# Altium schematic sheet PORT.SchDoc
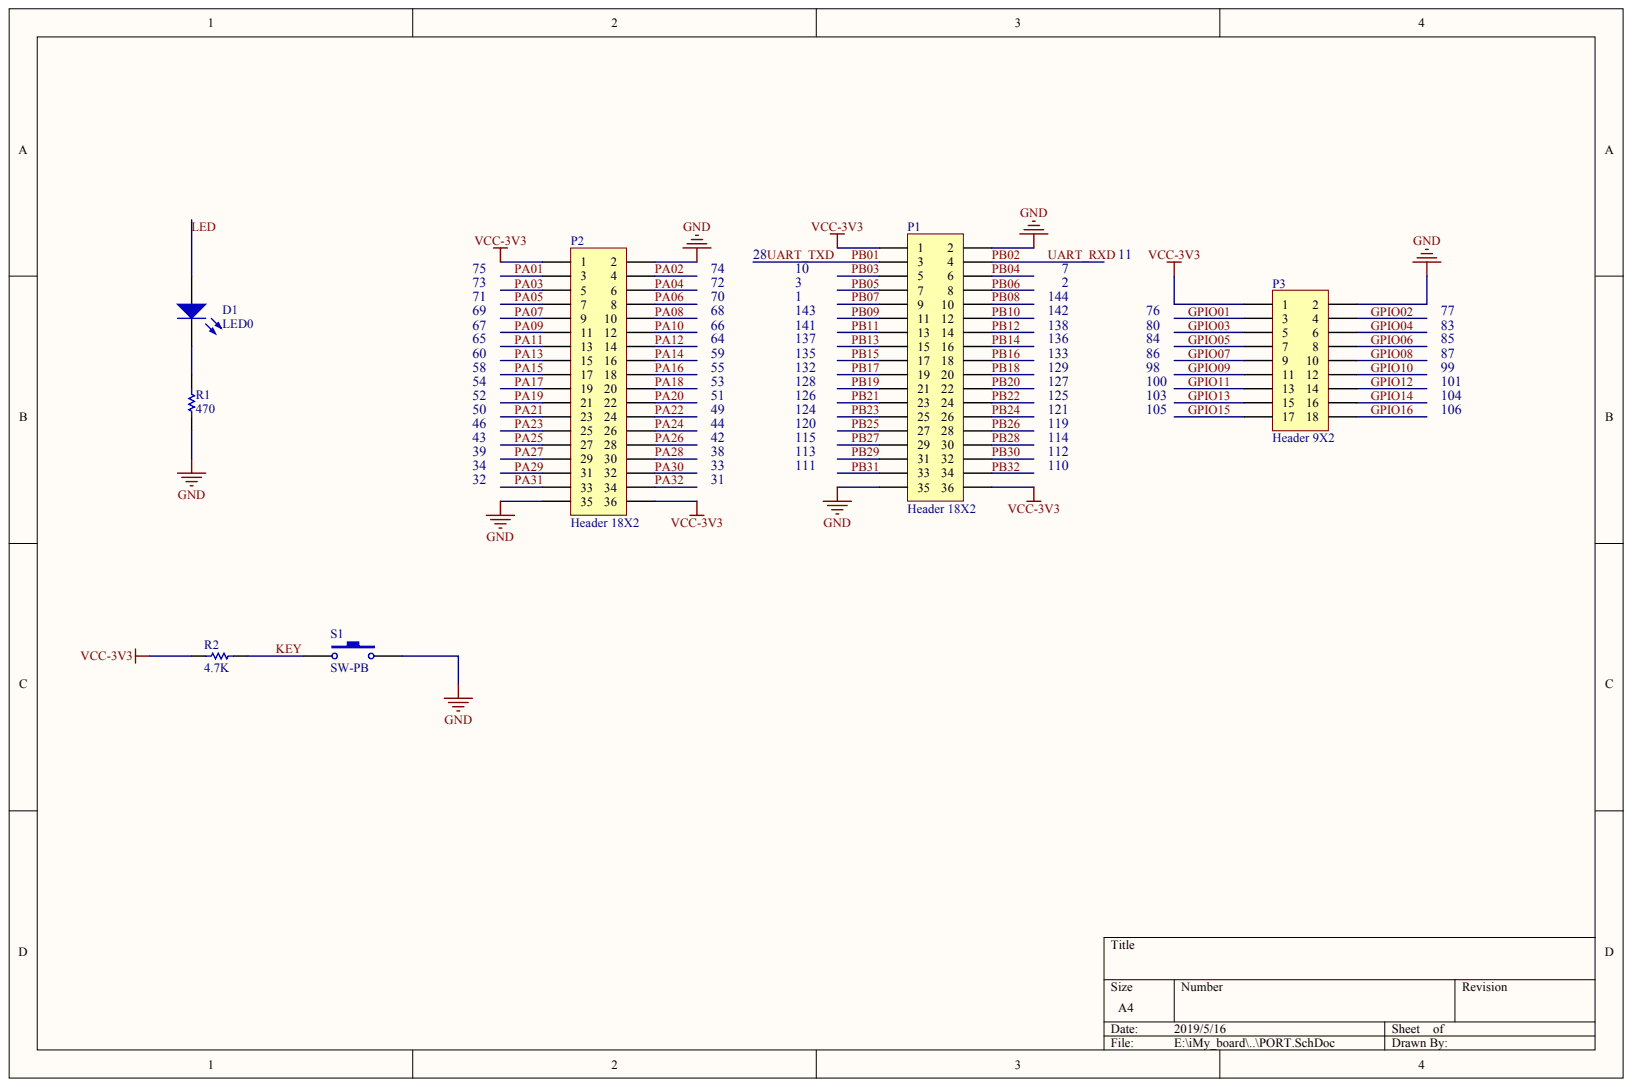
[diagram p0: PORT.SchDoc]

## Parts
D1  LED0
P1  Header 18X2
P2  Header 18X2
P3  Header 9X2
R1  value 470  (hidden comment: Res1)
R2  value 4.7K  (hidden comment: Res1)
S1  SW-PB

## Nets
GND: P1.2 P1.35 P2.2 P2.35 P3.2 R1.1 S1.2
VCC-3V3: P1.1 P1.36 P2.1 P2.36 P3.1 R2.2
GPIO01: P3.3
GPIO02: P3.4
GPIO03: P3.5
GPIO04: P3.6
GPIO05: P3.7
GPIO06: P3.8
GPIO07: P3.9
GPIO08: P3.10
GPIO09: P3.11
GPIO10: P3.12
GPIO11: P3.13
GPIO12: P3.14
GPIO13: P3.15
GPIO14: P3.16
GPIO15: P3.17
GPIO16: P3.18
KEY: R2.1 S1.1
LED: D1.1
PA01: P2.3
PA02: P2.4
PA03: P2.5
PA04: P2.6
PA05: P2.7
PA06: P2.8
PA07: P2.9
PA08: P2.10
PA09: P2.11
PA10: P2.12
PA11: P2.13
PA12: P2.14
PA13: P2.15
PA14: P2.16
PA15: P2.17
PA16: P2.18
PA17: P2.19
PA18: P2.20
PA19: P2.21
PA20: P2.22
PA21: P2.23
PA22: P2.24
PA23: P2.25
PA24: P2.26
PA25: P2.27
PA26: P2.28
PA27: P2.29
PA28: P2.30
PA29: P2.31
PA30: P2.32
PA31: P2.33
PA32: P2.34
PB01: P1.3
PB02: P1.4
PB03: P1.5
PB04: P1.6
PB05: P1.7
PB06: P1.8
PB07: P1.9
PB08: P1.10
PB09: P1.11
PB10: P1.12
PB11: P1.13
PB12: P1.14
PB13: P1.15
PB14: P1.16
PB15: P1.17
PB16: P1.18
PB17: P1.19
PB18: P1.20
PB19: P1.21
PB20: P1.22
PB21: P1.23
PB22: P1.24
PB23: P1.25
PB24: P1.26
PB25: P1.27
PB26: P1.28
PB27: P1.29
PB28: P1.30
PB29: P1.31
PB30: P1.32
PB31: P1.33
PB32: P1.34
NetD1_2: D1.2 R1.2

## Library data of the parts
D1 P1-P3 R1 R2 S1 = (no symbol name): Publisher: Altium Limited
  D1: Published: 8-Jun-2000; LatestRevisionDate: 08-Jul-2005; LatestRevisionNote: Mech Layer 1 removed.; PackageReference: LED-0; PackageDescription: LED; 2 Leads
  P1-P3: LatestRevisionDate: 17-Jul-2002; LatestRevisionNote: Re-released for DXP Platform.
  R1 R2: Published: 8-Jun-2000; LatestRevisionDate: 17-Jul-2002; LatestRevisionNote: Re-released for DXP Platform.; PackageReference: AXIAL-0.3; PackageDescription: Axial Device, Thru-Hole; 2 Leads; 0.3 in Pin Spacing
  S1: Published: 24-Mar-1999; LatestRevisionDate: 17-Jul-2002; LatestRevisionNote: Re-released for DXP Platform.; PackageReference: SPST-2; PackageDescription: Switch; 2 Leads
